# Altium schematic sheet STM32v2.SchDoc
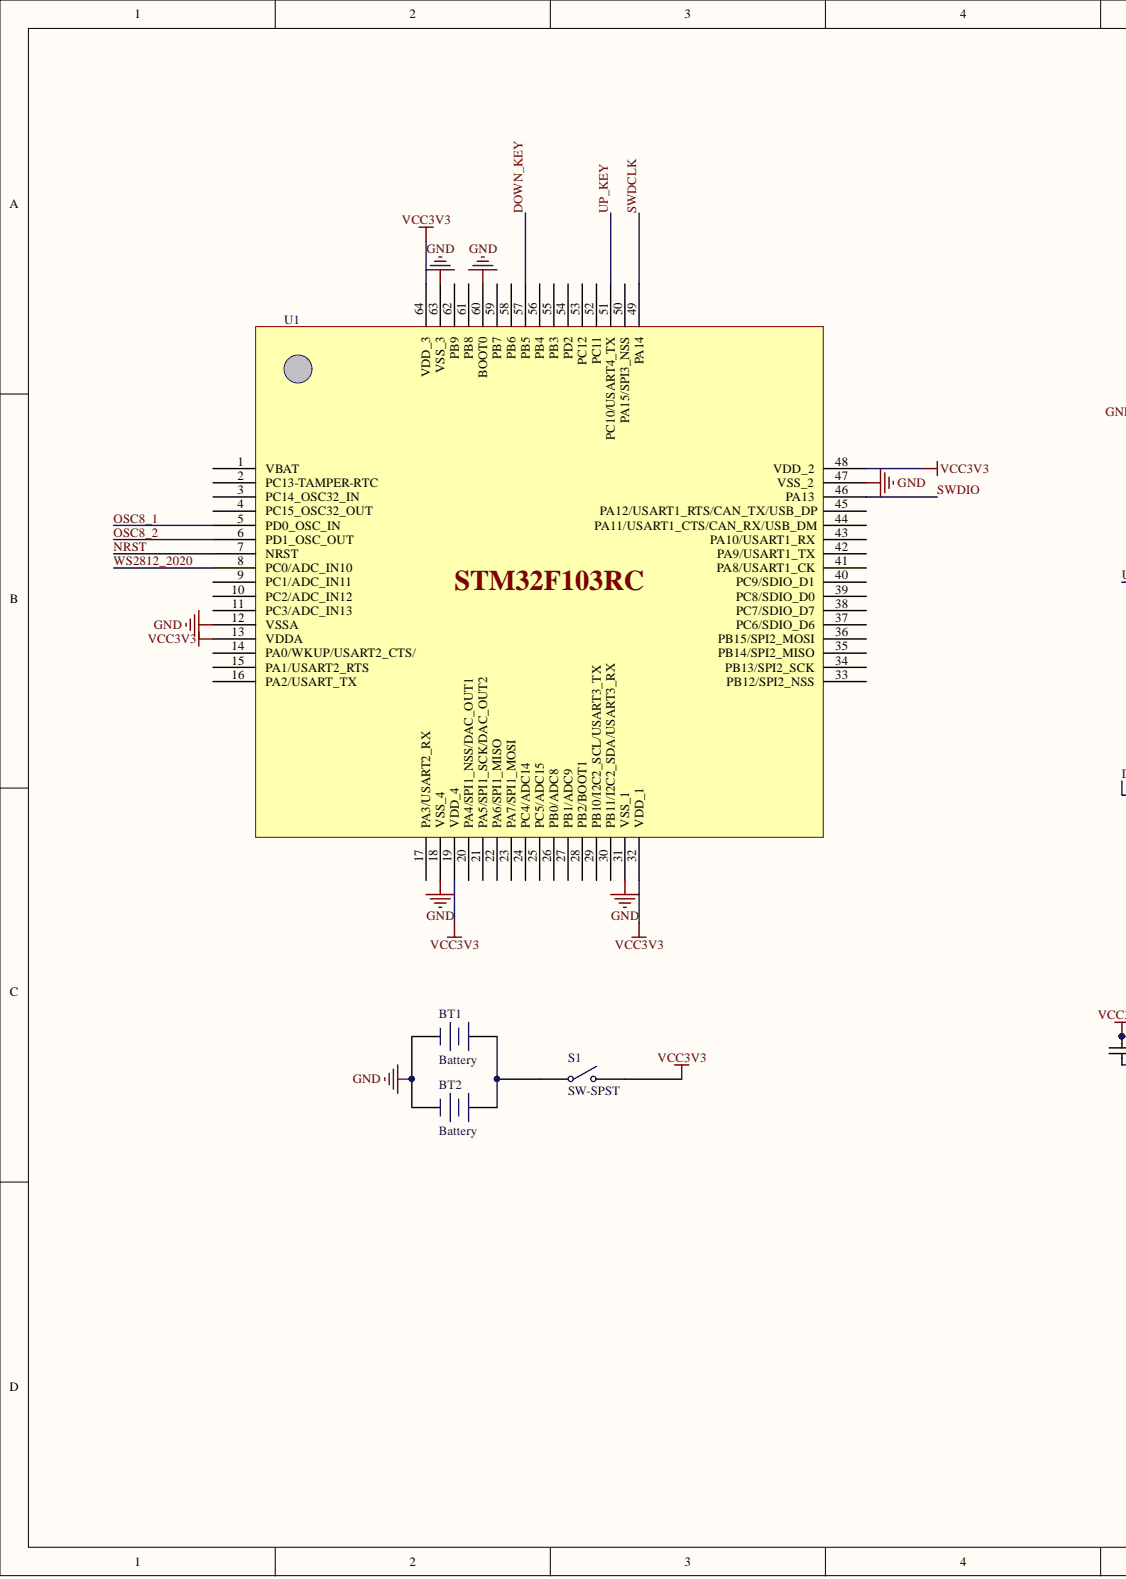
[diagram p0: STM32v2.SchDoc, left]
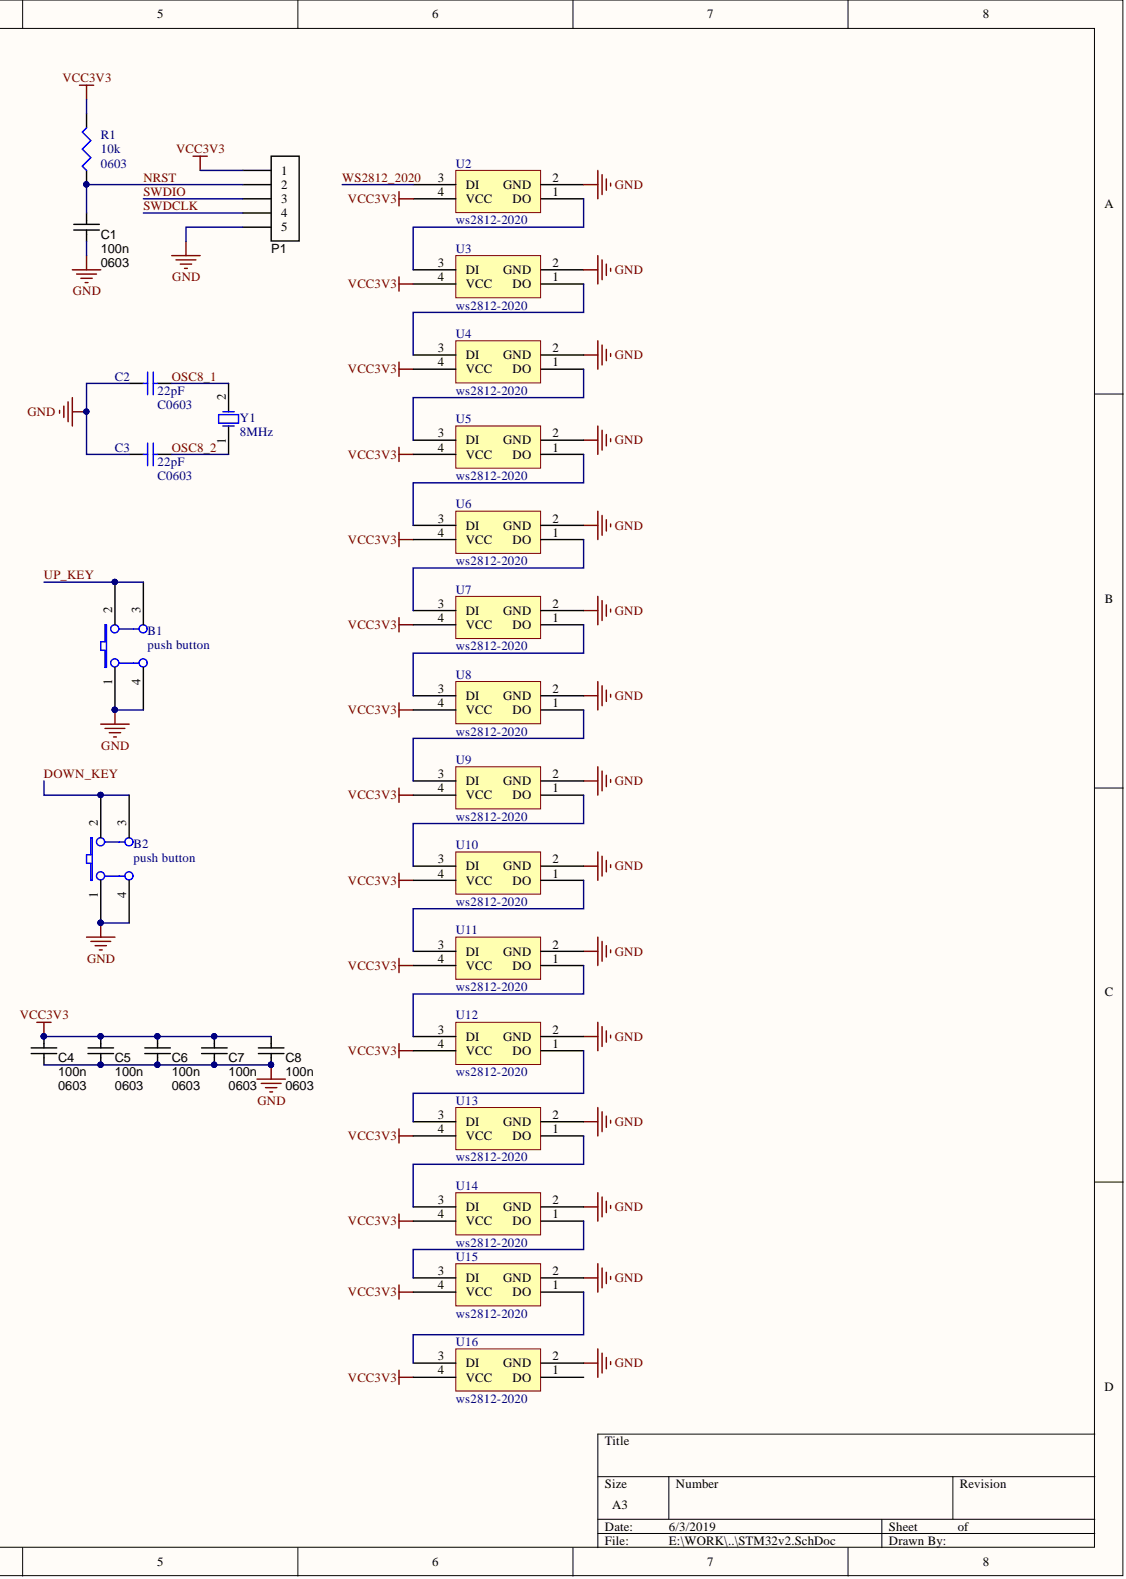
[diagram p1: STM32v2.SchDoc, right]

## Parts
B1  push button  [PB10]
B2  push button  [PB10]
BT1  Battery  [3034]
BT2  Battery  [3034]
C1  0603  value 100n  [C0603]
C2  C0603  value 22pF  [C0603]
C3  C0603  value 22pF  [C0603]
C4  0603  value 100n  [C0603]
C5  0603  value 100n  [C0603]
C6  0603  value 100n  [C0603]
C7  0603  value 100n  [C0603]
C8  0603  value 100n  [C0603]
P1  Programmer  [HDR1X5_2.54MMP]  (value not drawn)  (no BOM)
R1  0603  value 10k  [R0603]
S1  SW-SPST  [SW]
U1  STM32F103RC  [LQFP64_N]
U2  ws2812-2020  [WS2812_2020]
U3  ws2812-2020  [WS2812_2020]
U4  ws2812-2020  [WS2812_2020]
U5  ws2812-2020  [WS2812_2020]
U6  ws2812-2020  [WS2812_2020]
U7  ws2812-2020  [WS2812_2020]
U8  ws2812-2020  [WS2812_2020]
U9  ws2812-2020  [WS2812_2020]
U10  ws2812-2020  [WS2812_2020]
U11  ws2812-2020  [WS2812_2020]
U12  ws2812-2020  [WS2812_2020]
U13  ws2812-2020  [WS2812_2020]
U14  ws2812-2020  [WS2812_2020]
U15  ws2812-2020  [WS2812_2020]
U16  ws2812-2020  [WS2812_2020]
Y1  8MHz  [ABM3]

## Nets
GND: B1.1 B1.4 B2.1 B2.4 BT1.2 BT2.2 C1.1 C2.2 C3.2 C4.1 C5.1 C6.1 C7.1 C8.1 P1.5 U1.12(VSSA) U1.18(VSS_4) U1.31(VSS_1) U1.47(VSS_2) U1.60(BOOT0) U1.63(VSS_3) U2.2(GND) U3.2(GND) U4.2(GND) U5.2(GND) U6.2(GND) U7.2(GND) U8.2(GND) U9.2(GND) U10.2(GND) U11.2(GND) U12.2(GND) U13.2(GND) U14.2(GND) U15.2(GND) U16.2(GND)
VCC3V3: C4.2 C5.2 C6.2 C7.2 C8.2 P1.1 R1.2 S1.2 U1.13(VDDA) U1.19(VDD_4) U1.32(VDD_1) U1.48(VDD_2) U1.64(VDD_3) U2.4(VCC) U3.4(VCC) U4.4(VCC) U5.4(VCC) U6.4(VCC) U7.4(VCC) U8.4(VCC) U9.4(VCC) U10.4(VCC) U11.4(VCC) U12.4(VCC) U13.4(VCC) U14.4(VCC) U15.4(VCC) U16.4(VCC)
DOWN_KEY: B2.2 B2.3 U1.57(PB5)
NRST: C1.2 P1.2 R1.1 U1.7(NRST)
OSC8_1: C2.1 U1.5(PD0_OSC_IN) Y1.2
OSC8_2: C3.1 U1.6(PD1_OSC_OUT) Y1.1
SWDCLK: P1.4 U1.49(PA14)
SWDIO: P1.3 U1.46(PA13)
UP_KEY: B1.2 B1.3 U1.51(PC10/USART4_TX)
WS2812_2020: U1.8(PC0/ADC_IN10) U2.3(DI)
NetBT1_1: BT1.1 BT2.1 S1.1
NetU2_1: U2.1(DO) U3.3(DI)
NetU3_1: U3.1(DO) U4.3(DI)
NetU4_1: U4.1(DO) U5.3(DI)
NetU5_1: U5.1(DO) U6.3(DI)
NetU6_1: U6.1(DO) U7.3(DI)
NetU7_1: U7.1(DO) U8.3(DI)
NetU8_1: U8.1(DO) U9.3(DI)
NetU9_1: U9.1(DO) U10.3(DI)
NetU10_1: U10.1(DO) U11.3(DI)
NetU11_1: U11.1(DO) U12.3(DI)
NetU12_1: U12.1(DO) U13.3(DI)
NetU13_1: U13.1(DO) U14.3(DI)
NetU14_1: U14.1(DO) U15.3(DI)
NetU15_1: U15.1(DO) U16.3(DI)

Not connected: U1 1(VBAT) 2(PC13-TAMPER-RTC) 3(PC14_OSC32_IN) 4(PC15_OSC32_OUT) 9(PC1/ADC_IN11) 10(PC2/ADC_IN12) 11(PC3/ADC_IN13) 14(PA0/WKUP/USART2_CTS/) 15(PA1/USART2_RTS) 16(PA2/USART_TX) 17(PA3/USART2_RX) 20(PA4/SPI1_NSS/DAC_OUT1) 21(PA5/SPI1_SCK/DAC_OUT2) 22(PA6/SPI1_MISO) 23(PA7/SPI1_MOSI) 24(PC4/ADC14) 25(PC5/ADC15) 26(PB0/ADC8) 27(PB1/ADC9) 28(PB2/BOOT1) 29(PB10/I2C2_SCL/USART3_TX) 30(PB11/I2C2_SDA/USART3_RX) 33(PB12/SPI2_NSS) 34(PB13/SPI2_SCK) 35(PB14/SPI2_MISO) 36(PB15/SPI2_MOSI) 37(PC6/SDIO_D6) 38(PC7/SDIO_D7) 39(PC8/SDIO_D0) 40(PC9/SDIO_D1) 41(PA8/USART1_CK) 42(PA9/USART1_TX) 43(PA10/USART1_RX) 44(PA11/USART1_CTS/CAN_RX/USB_DM) 45(PA12/USART1_RTS/CAN_TX/USB_DP) 50(PA15/SPI3_NSS) 52(PC11) 53(PC12) 54(PD2) 55(PB3) 56(PB4) 58(PB6) 59(PB7) 61(PB8) 62(PB9); U16 1(DO)

## Library data of the parts
B1 B2 = SW PUSHBUTTON: SE PUSHBUTTON; Component Kind: Standard; Library Name: Documents.SCHLIB
BT1 BT2 = Battery: Multicell Battery; Component Kind: Standard; LatestRevisionDate: 17-Jul-2002; LatestRevisionNote: Re-released for DXP Platform.; Library Name: Miscellaneous Devices.IntLib; PackageDescription: Battery; 2 Leads; PackageReference: BAT-2; Published: 24-Mar-1999; Publisher: Altium Limited
C1 C4-C8 = CAPC_100n_0603_X7R_50V_10%: Component Kind: Standard; Library Name: PBL - Capacitors.SCHLIB
C2 C3 = Cap_0603: Capacitor; Component Kind: Standard; Library Name: Miscellaneous Devices.SchLib
P1 = Header5P: Header 5-pin; Library Name: PBL - Connectors.SCHLIB
R1 = Res3: Resistor; Component Kind: Standard; Library Name: Miscellaneous Devices.IntLib; Signal Integrity: Res; Simulation: RESISTOR
S1 = SW-SPST: Single-Pole, Single-Throw Switch; Component Kind: Standard; LatestRevisionDate: 17-Jul-2002; LatestRevisionNote: Re-released for DXP Platform.; Library Name: Miscellaneous Devices.IntLib; PackageDescription: Switch; 2 Leads; PackageReference: SPST-2; Published: 24-Mar-1999; Publisher: Altium Limited
Y1 = XTAL: Crystal Oscillator; Component Kind: Standard; Library Name: Miscellaneous Devices.IntLib
U1 = STM32F103RC: Component Kind: Standard; Library Name: STM32F103RC.SchLib
U2-U16 = ws2812-2020: Component Kind: Standard; Library Name: Schlib1.SchLib
